# Altium schematic sheet Top level.SchDoc("Top level")
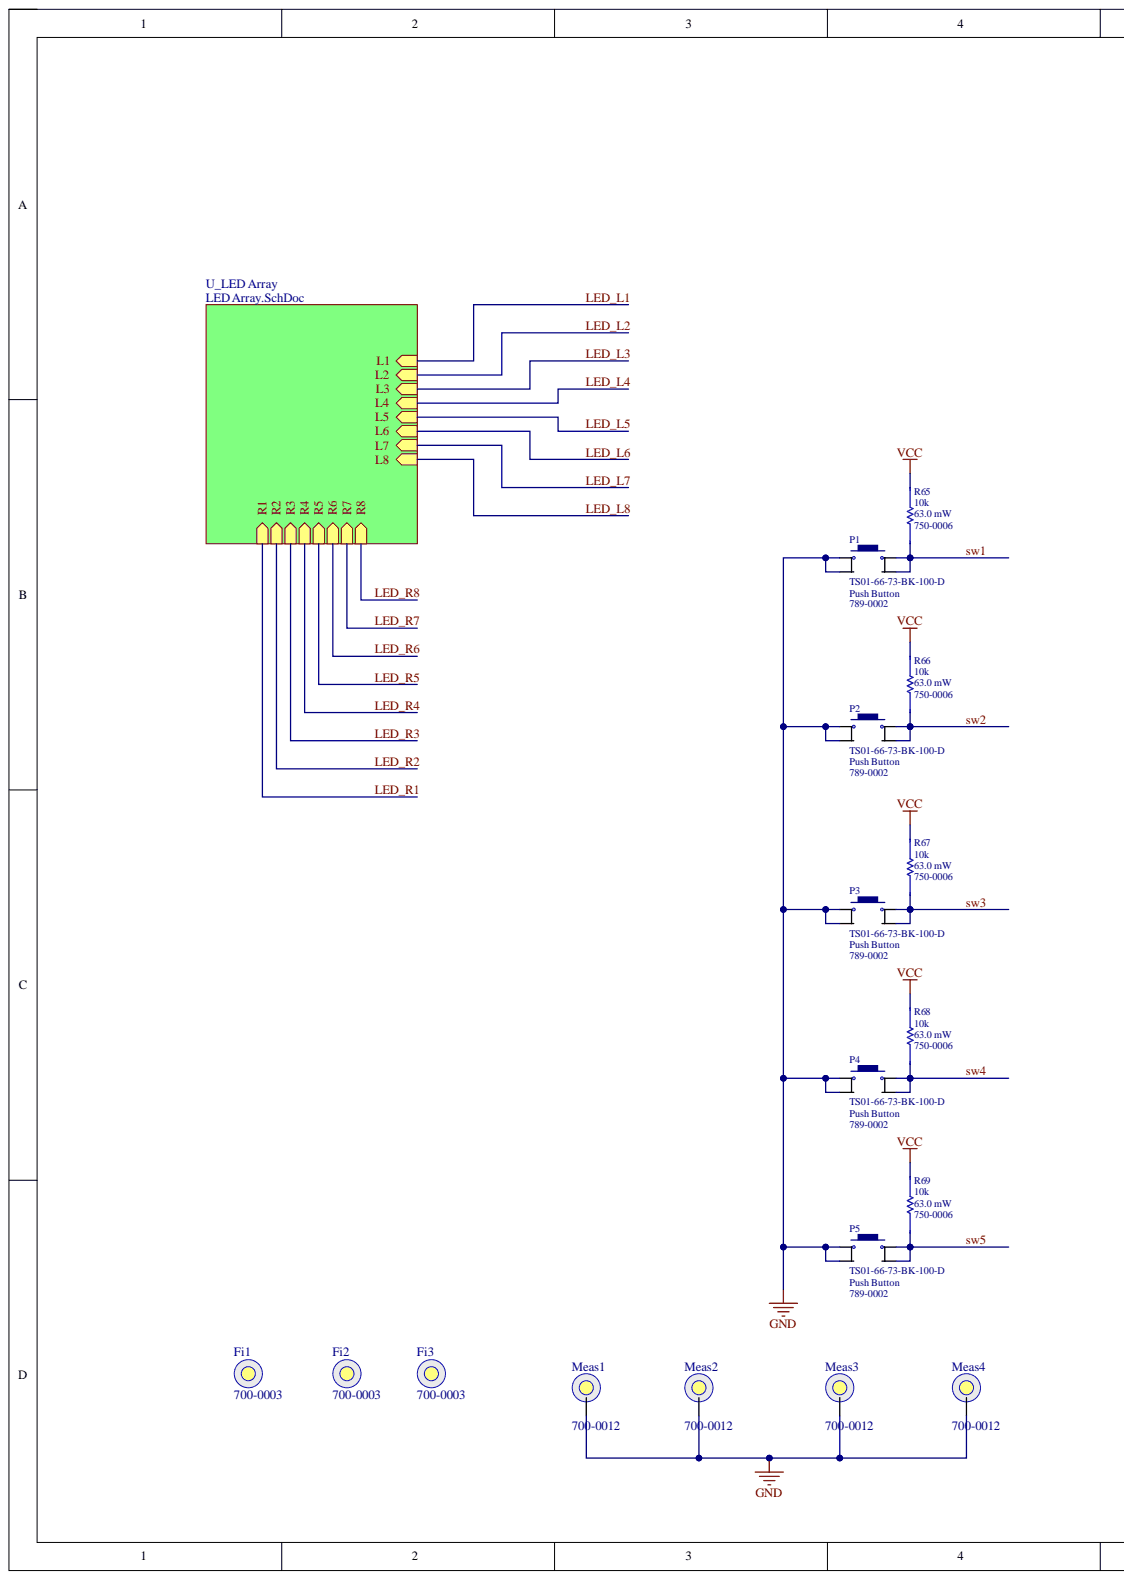
[diagram p0: Top level.SchDoc, left]
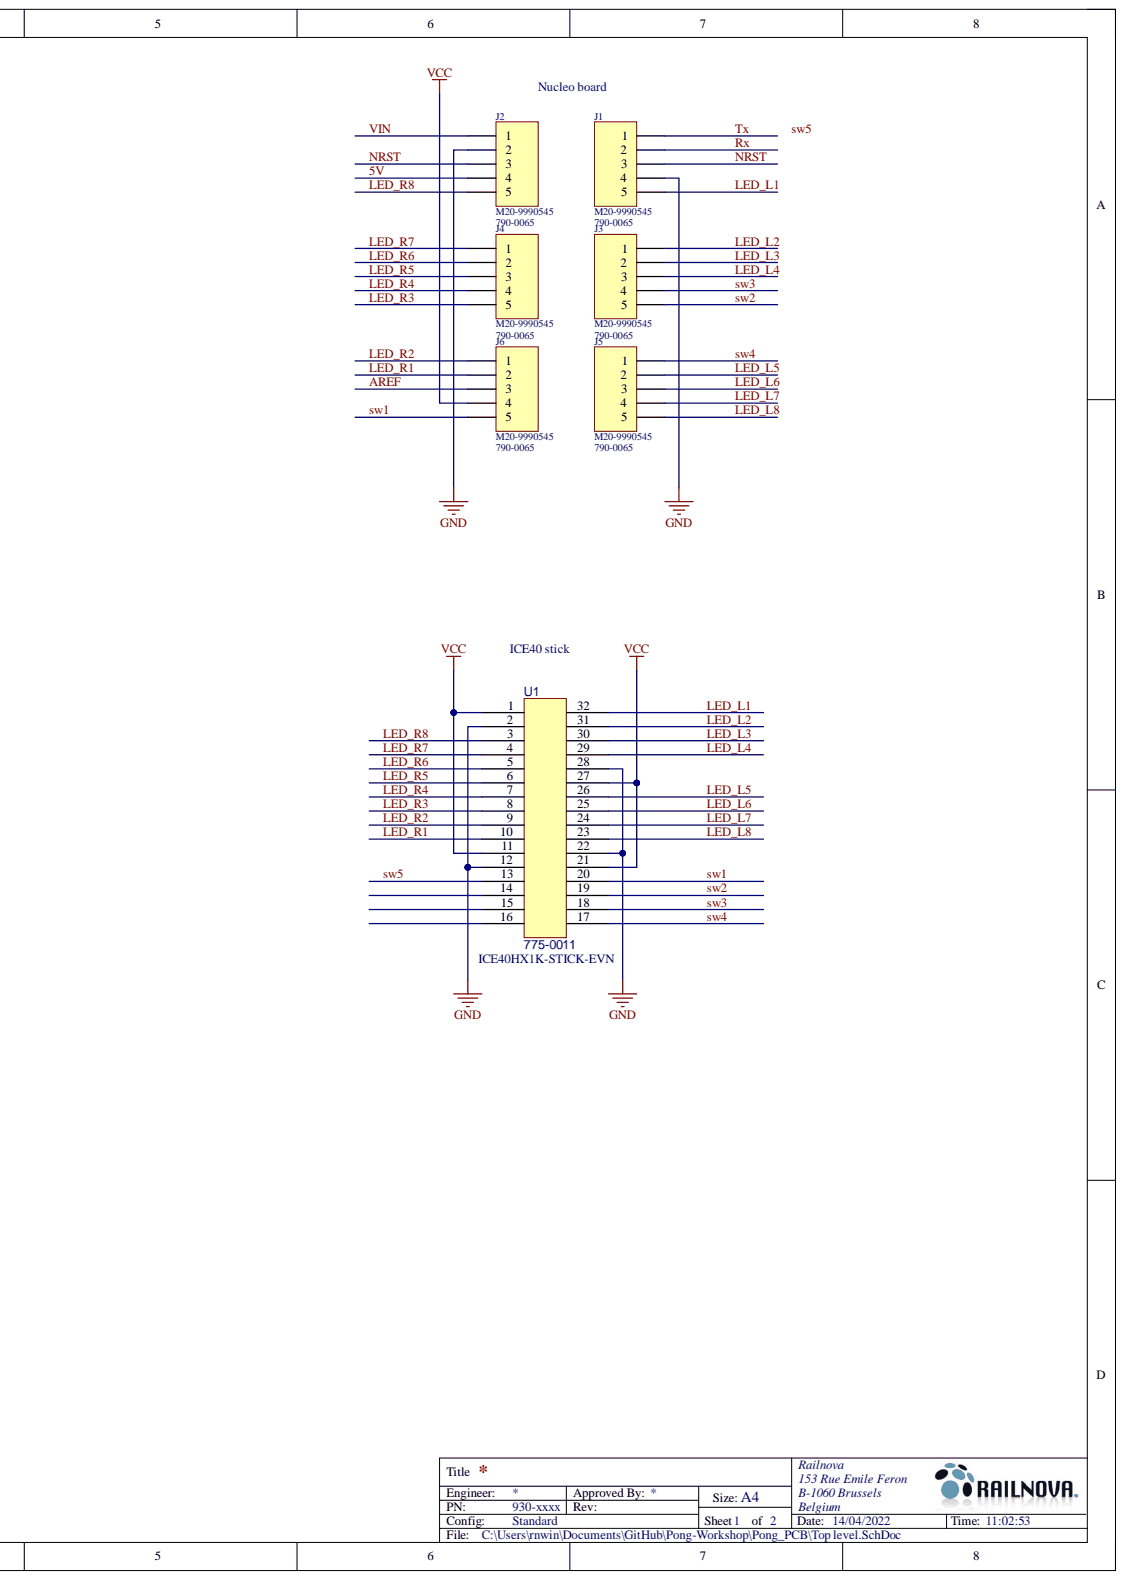
[diagram p1: Top level.SchDoc, right]

## Parts
Fi1  700-0003  [Fiducial_1mm]
Fi2  700-0003  [Fiducial_1mm]
Fi3  700-0003  [Fiducial_1mm]
J1  M20-9990545  [CON-HDR-VER-M1X5]
J2  M20-9990545  [CON-HDR-VER-M1X5]
J3  M20-9990545  [CON-HDR-VER-M1X5]
J4  M20-9990545  [CON-HDR-VER-M1X5]
J5  M20-9990545  [CON-HDR-VER-M1X5]
J6  M20-9990545  [CON-HDR-VER-M1X5]
Meas1  700-0012  [FOX_measure_point_3mm]
Meas2  700-0012  [FOX_measure_point_3mm]
Meas3  700-0012  [FOX_measure_point_3mm]
Meas4  700-0012  [FOX_measure_point_3mm]
P1  TS01-66-73-BK-100-D  Component Type: Push Button  [TS01-66-70-BK]
P2  TS01-66-73-BK-100-D  Component Type: Push Button  [TS01-66-70-BK]
P3  TS01-66-73-BK-100-D  Component Type: Push Button  [TS01-66-70-BK]
P4  TS01-66-73-BK-100-D  Component Type: Push Button  [TS01-66-70-BK]
P5  TS01-66-73-BK-100-D  Component Type: Push Button  [TS01-66-70-BK]
R65  10k  Pmax: 63.0 mW  [R0402-IPC-MD]
R66  10k  Pmax: 63.0 mW  [R0402-IPC-MD]
R67  10k  Pmax: 63.0 mW  [R0402-IPC-MD]
R68  10k  Pmax: 63.0 mW  [R0402-IPC-MD]
R69  10k  Pmax: 63.0 mW  [R0402-IPC-MD]
U1  775-0011  MPN: ICE40HX1K-STICK-EVN  [ICE-stick]

## Nets
GND: J1.4 J2.2 Meas1.pad Meas2.pad Meas3.pad Meas4.pad P1.1 P1.2 P2.1 P2.2 P3.1 P3.2 P4.1 P4.2 P5.1 P5.2 U1.2 U1.12 U1.22 U1.28
VCC: J6.4 R65.2 R66.2 R67.2 R68.2 R69.2 U1.1 U1.11 U1.21 U1.27
5V: J2.4
AREF: J6.3
LED_L1: J1.5 U1.32
LED_L2: J3.1 U1.31
LED_L3: J3.2 U1.30
LED_L4: J3.3 U1.29
LED_L5: J5.2 U1.26
LED_L6: J5.3 U1.25
LED_L7: J5.4 U1.24
LED_L8: J5.5 U1.23
LED_R1: J6.2 U1.10
LED_R2: J6.1 U1.9
LED_R3: J4.5 U1.8
LED_R4: J4.4 U1.7
LED_R5: J4.3 U1.6
LED_R6: J4.2 U1.5
LED_R7: J4.1 U1.4
LED_R8: J2.5 U1.3
NRST: J1.3 J2.3
Rx: J1.2
sw1: J6.5 P1.3 P1.4 R65.1 U1.20
sw2: J3.5 P2.3 P2.4 R66.1 U1.19
sw3: J3.4 P3.3 P3.4 R67.1 U1.18
sw4: J5.1 P4.3 P4.4 R68.1 U1.17
sw5: P5.3 P5.4 R69.1 U1.13
Tx: J1.1
VIN: J2.1
NetU1_14: U1.14
NetU1_15: U1.15
NetU1_16: U1.16

## Library data of the parts
Fi1-Fi3 = 700-0003: Fiducial; Database Table Name: rnlib2.misc; Component Kind: Standard; Component Type: Fiducial; ComponentLink1Description: ACM; ComponentLink1URL: https://acm.railnova.io/admin/altium_library/component/3/change/; Library Name: rnlib2.DbLib; MPN: Fiducial; MSL: NA; Package: Fiducial; Part Number: 700-0003; Pin Count: 1; Rev: A; Revision: A; Revision Date: 2020-09-14; Revision Note: Created; Status: Review
J1-J6 = 790-0065: CONN REC HDR VERTICAL MALE 1X5 P0.1in; Manufacturer: HARWIN; Cols: 5; Database Table Name: rnlib2.connectors; Imax: 3A; Pitch: 0.1in; Rows: 1; Vmax: 750V; Angle: VERTICAL; Component Kind: Standard; Component Type: REC; ComponentLink1Description: ACM; ComponentLink1URL: https://acm.railnova.io/admin/altium_library/component/326/change/; Gender: MALE; Library Name: rnlib2.DbLib; MPN: M20-9990545; MSL: 1; Package: HDR 2.54mm; Part Number: 790-0065; Pin Count: 5; Rev: A; Revision: A; Revision Date: 2020-09-14; Revision Note: Created; Status: Review; Style: HDR
Meas1-Meas4 = 700-0012: Measuring point for FOX, 3mm without soldermask; MPN: MeasurePoint; Database Table Name: rnlib2.misc; Component Kind: Standard; Component Type: Fiducial; ComponentLink1Description: ACM; ComponentLink1URL: https://acm.railnova.io/admin/altium_library/component/448/change/; Library Name: rnlib2.DbLib; MSL: NA; Package: 3mm; Part Number: 700-0012; Pin Count: 1; Rev: C; Revision: D; Revision Date: 2021-12-03; Revision Note: Schematic FOX_measure_point_3mm was updated on EDA-rnlib2 repository.; Status: Review
P1-P5 = 789-0002: Push Button 6 x 6 mm, 7 mm Act Height, 100 gf, Black, Short Crimped, Through Hole, SPST; Database Table Name: rnlib2.switches; Imax: 50mA; Pmax: 0.6W; Resistance: 100mOhm; Vmax: 12V; Component Kind: Standard; ComponentLink1Description: ACM; ComponentLink1URL: https://acm.railnova.io/admin/altium_library/component/498/change/; ComponentLink2Description: Datasheet; ComponentLink2URL: https://www.mouser.be/datasheet/2/670/ts01-2586199.pdf; Library Name: rnlib2.DbLib; MPN: TS01-66-73-BK-100-D; MSL: 1; Package: WS-TUS; Part Number: 789-0002; Pin Count: 4; Rev: A; Revision: B; Revision Date: 2022-04-14; Revision Note: ok; Status: Review; Style: Through Hole
R65-R69 = 750-0006: Resistor 10k 0402 1% 63.0 mW; Manufacturer: VISHAY; MPN: CRCW040210K0FKED; Database Table Name: rnlib2.resistors; Tcoef: 100 ppm/C; Vmax: 50.0 V; Component Kind: Standard; Component Type: Thick Film; ComponentLink1Description: ACM; ComponentLink1URL: https://acm.railnova.io/admin/altium_library/component/109/change/; Library Name: rnlib2.DbLib; MSL: 1; Package: 0402; Part Number: 750-0006; Pin Count: 2; Rev: A; Revision: C; Revision Date: 2021-04-21; Revision Note: OK; Status: Active; Tolerance: 1%; Value: 10k
U1 = 775-0011: iCE40 FPGA USB stick; Manufacturer: LATTICE SEMICONDUCTOR; Part Description: IEEE 802.11a/b/g/n Wi-Fi, Bluetooth 3.0+HS Module; Database Table Name: rnlib2.som; Component Kind: Standard; Component Type: SOM; ComponentLink1Description: ACM; ComponentLink1URL: https://acm.railnova.io/admin/altium_library/component/501/change/; ComponentLink2Description: Datasheet; ComponentLink2URL: https://www.farnell.com/datasheets/1737019.pdf; Library Name: rnlib2.DbLib; MSL: NA; Package: SOM; Part Number: 775-0011; Pin Count: 32; Rev: A; Revision: A; Revision Date: 2022-04-14; Status: Draft; Revision Note: Created by Abdellah Azaanoun
